# Altium schematic sheet Main.SchDoc
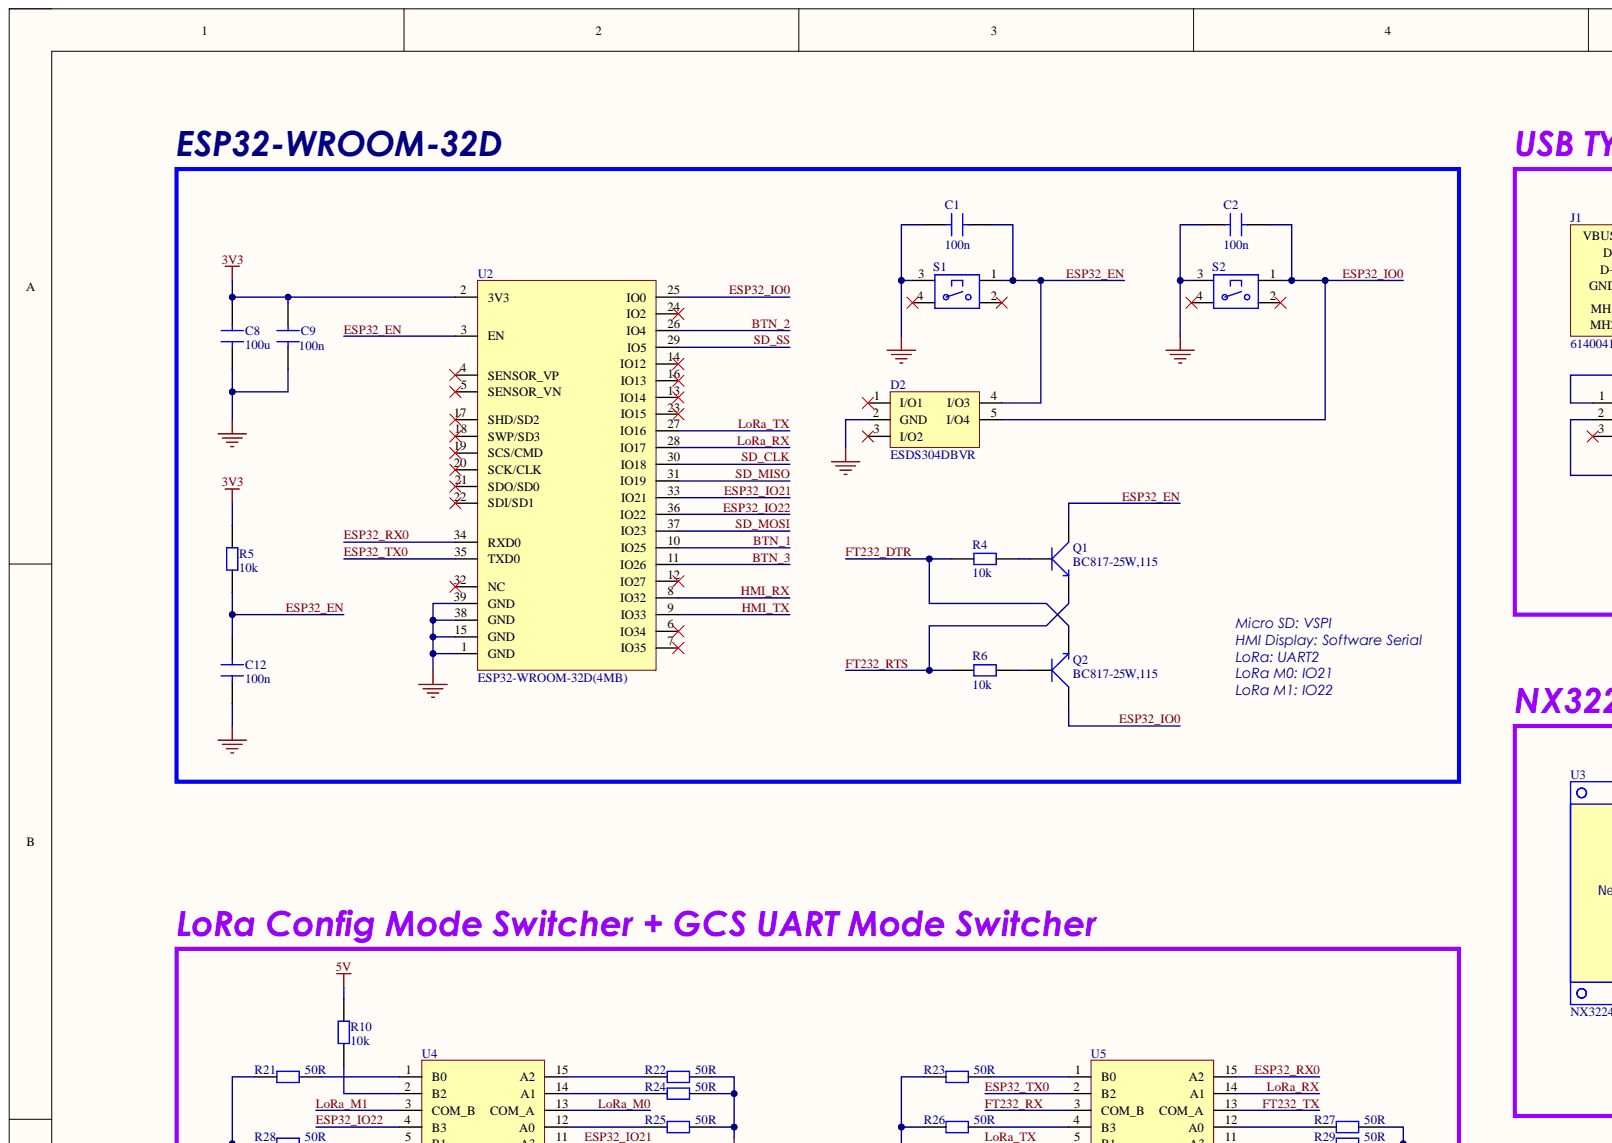
[diagram p0: Main.SchDoc, top left]
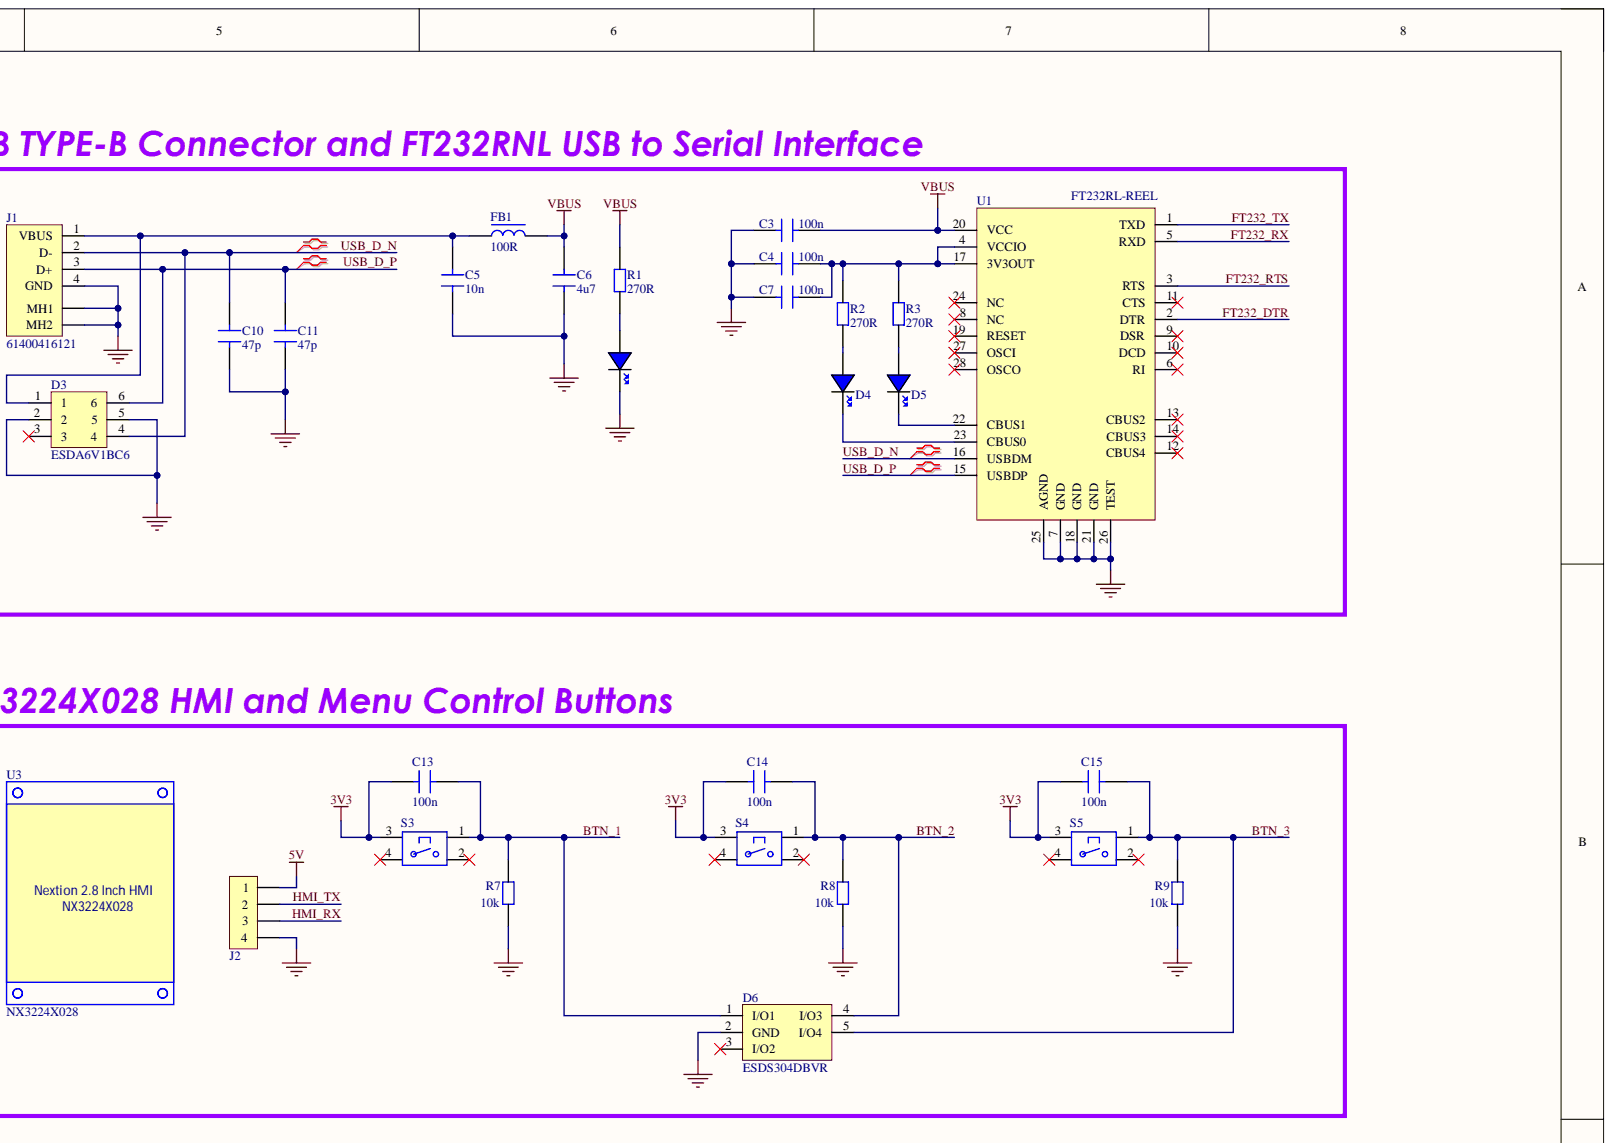
[diagram p1: Main.SchDoc, top right]
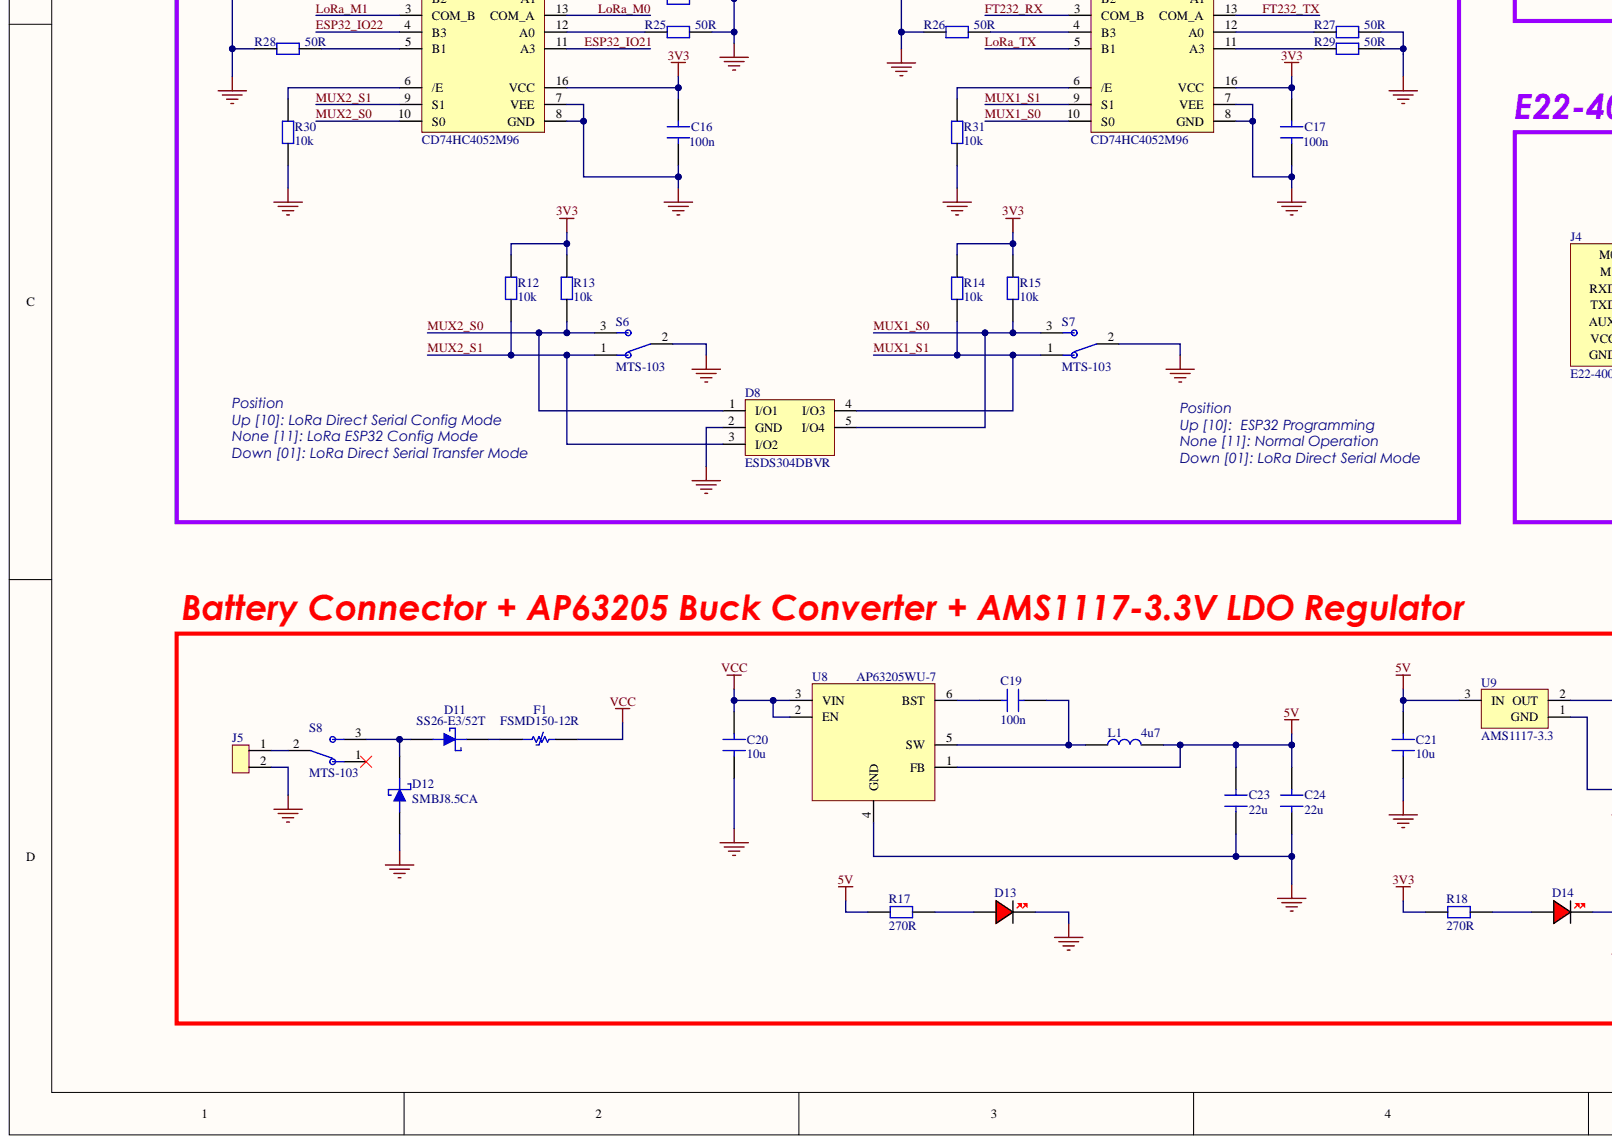
[diagram p2: Main.SchDoc, bottom left]
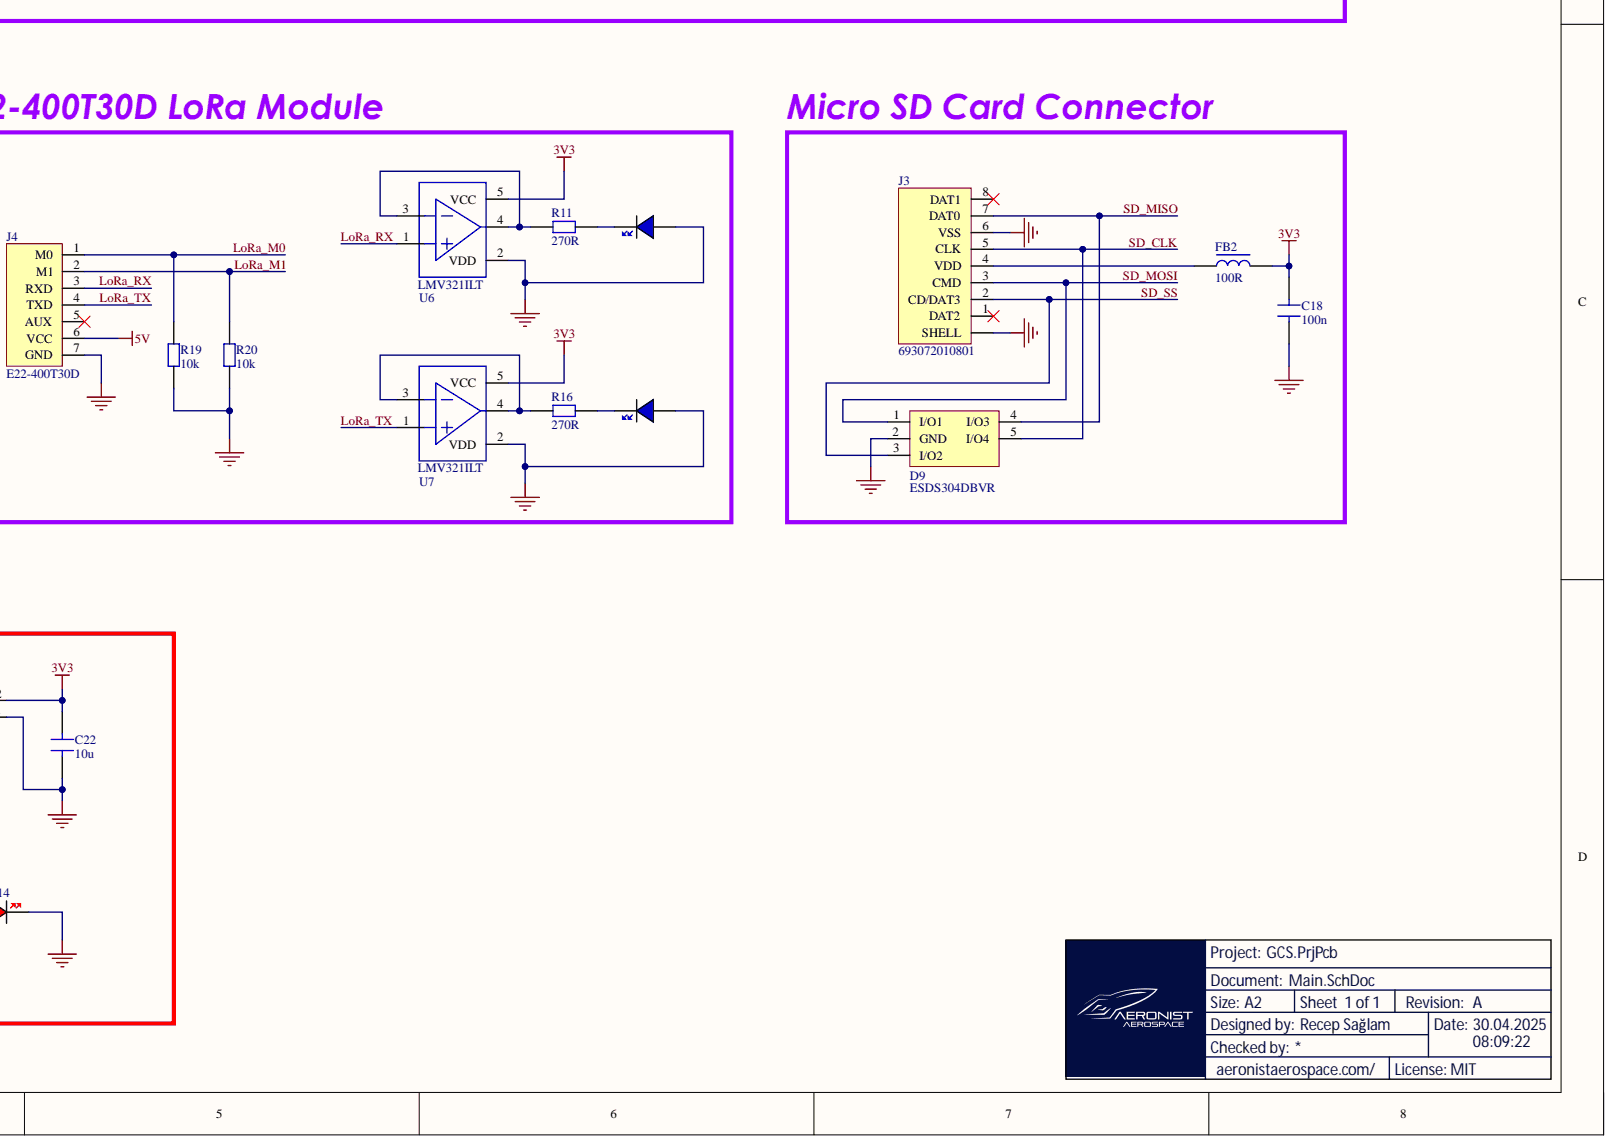
[diagram p3: Main.SchDoc, bottom right]

## Parts
C1  100n  [CAP 0805]
C2  100n  [CAP 0805]
C3  100n  [CAP 0805]
C4  100n  [CAP 0805]
C5  10n  [CAP 0805]
C6  4u7  [CAP 0805]
C7  100n  [CAP 0805]
C8  100u  [CAP 1210]
C9  100n  [CAP 0805]
C10  47p  [CAP 0805]
C11  47p  [CAP 0805]
C12  100n  [CAP 0805]
C13  100n  [CAP 0805]
C14  100n  [CAP 0805]
C15  100n  [CAP 0805]
C16  100n  [CAP 0805]
C17  100n  [CAP 0805]
C18  100n  [CAP 0805]
C19  100n  [CAP 0805]
C20  10u  [CAP 1210]
C21  10u  [CAP 0805]
C22  10u  [CAP 0805]
C23  22u  [CAP 1210]
C24  22u  [CAP 1210]
D1  LED 0805 BLUE  [LED 0805 BLUE]  (value not drawn)
D2  ESDS304DBVR  [SOT-23-5]
D3  ESDA6V1BC6  [SOT-23-6]
D4  LED 0805 BLUE  [LED 0805 BLUE]  (value not drawn)
D5  LED 0805 BLUE  [LED 0805 BLUE]  (value not drawn)
D6  ESDS304DBVR  [SOT-23-5]
D7  LED 0805 BLUE  [LED 0805 BLUE]  (value not drawn)
D8  ESDS304DBVR  [SOT-23-5]
D9  ESDS304DBVR  [SOT-23-5]
D10  LED 0805 BLUE  [LED 0805 BLUE]  (value not drawn)
D11  SS26-E3/52T  [DO-214AA]
D12  SMBJ8.5CA  [SMBJ12CA-13-F]
D13  LED 0805 RED  [LED 0805 RED]  (value not drawn)
D14  LED 0805 RED  [LED 0805 RED]  (value not drawn)
F1  FSMD150-12R  [0ZCG0075FF2C]
FB1  100R  [FB 0805]
FB2  100R  [FB 0805]
J1  61400416121  [61400416121]
J2  1x4 HEADER MALE  [1X4 HEADER MALE]  (value not drawn)
J3  693072010801  [693072010801]
J4  E22-400T30D  [LoRa E22-433T30D H]
J5  KF350 2P Terminal  [KF350 2P]  (value not drawn)
L1  4u7  [IHLP2020CZER4R7M11]
Q1  BC817-25W,115  [BC817-25]
Q2  BC817-25W,115  [BC817-25]
R1  270R  [RES 0805]
R2  270R  [RES 0805]
R3  270R  [RES 0805]
R4  10k  [RES 0805]
R5  10k  [RES 0805]
R6  10k  [RES 0805]
R7  10k  [RES 0805]
R8  10k  [RES 0805]
R9  10k  [RES 0805]
R10  10k  [RES 0805]
R11  270R  [RES 0805]
R12  10k  [RES 0805]
R13  10k  [RES 0805]
R14  10k  [RES 0805]
R15  10k  [RES 0805]
R16  270R  [RES 0805]
R17  270R  [RES 0805]
R18  270R  [RES 0805]
R19  10k  [RES 0805]
R20  10k  [RES 0805]
R21  50R  [RES 0805]
R22  50R  [RES 0805]
R23  50R  [RES 0805]
R24  50R  [RES 0805]
R25  50R  [RES 0805]
R26  50R  [RES 0805]
R27  50R  [RES 0805]
R28  50R  [RES 0805]
R29  50R  [RES 0805]
R30  10k  [RES 0805]
R31  10k  [RES 0805]
S1  FSM4JSMATR  [FSM4JSMATR]  (value not drawn)
S2  FSM4JSMATR  [FSM4JSMATR]  (value not drawn)
S3  FSM4JSMATR  [FSM4JSMATR]  (value not drawn)
S4  FSM4JSMATR  [FSM4JSMATR]  (value not drawn)
S5  FSM4JSMATR  [FSM4JSMATR]  (value not drawn)
S6  MTS-103  [MTS-103]
S7  MTS-103  [MTS-103]
S8  MTS-103  [MTS-103]
U1  FT232RL-REEL  [FT232RL]
U2  ESP32-WROOM-32D(4MB)  [ESP32-WROOM-32]
U3  NX3224X028  [NX32224X028]
U4  CD74HC4052M96  [SOIC-16]
U5  CD74HC4052M96  [SOIC-16]
U6  LMV321ILT  [SOT-23-5]
U7  LMV321ILT  [SOT-23-5]
U8  AP63205WU-7  [AP63205]
U9  AMS1117-3.3  [SOT-223]

## Nets
3V3: C8.2 C9.2 C13.2 C14.1 C15.2 C16.2 C17.2 C18.1 C22.1 FB2.2 R5.2 R12.2 R13.2 R14.2 R15.2 R18.1 S3.3 S4.3 S5.3 U2.2(3V3) U4.16(VCC) U5.16(VCC) U6.5(VCC) U7.5(VCC) U9.2
5V: C21.1 C23.2 C24.2 J2.1 J4.6(VCC) L1.2 R10.2 R17.1 U8.1(FB) U9.3
GND: C1.2 C2.2 C3.2 C4.2 C5.1 C6.1 C7.2 C8.1 C9.1 C10.1 C11.1 C12.2 C16.1 C17.1 C18.2 C20.1 C21.2 C22.2 C23.1 C24.1 D1.K D2.2(GND) D3.2 D3.5 D6.2(GND) D7.A D8.2(GND) D9.2(GND) D10.A D12.1 D13.K D14.K J1.4(GND) J1.MH1 J1.MH2 J2.4 J3.6(VSS) J3.SHELL J4.7(GND) J5.2 R7.2 R8.2 R9.2 R19.1 R20.1 R21.2 R22.1 R23.2 R24.1 R25.1 R26.2 R27.1 R28.2 R29.1 R30.1 R31.1 S1.3 S2.3 S6.2 S7.2 U1.7(GND) U1.18(GND) U1.21(GND) U1.25(AGND) U1.26(TEST) U2.1(GND) U2.15(GND) U2.38(GND) U2.39(GND) U4.7(VEE) U4.8(GND) U5.7(VEE) U5.8(GND) U6.2(VDD) U7.2(VDD) U8.4(GND) U9.1
VBUS: C3.1 C6.2 FB1.2 R1.1 U1.20(VCC)
VCC: C20.2 F1.2 U8.2(EN) U8.3(VIN)
BTN_1: C13.1 D6.1(I/O1) R7.1 S3.1 U2.10(IO25)
BTN_2: C14.2 D6.4(I/O3) R8.1 S4.1 U2.26(IO4)
BTN_3: C15.1 D6.5(I/O4) R9.1 S5.1 U2.11(IO26)
ESP32_EN: C1.1 C12.1 D2.4(I/O3) Q1.3 R5.1 S1.1 U2.3(EN)
ESP32_IO0: C2.1 D2.5(I/O4) Q2.3 S2.1 U2.25(IO0)
ESP32_IO21: U2.33(IO21) U4.11(A3)
ESP32_IO22: U2.36(IO22) U4.4(B3)
ESP32_RX0: U2.34(RXD0) U5.15(A2)
ESP32_TX0: U2.35(TXD0) U5.2(B2)
FT232_DTR: Q2.2 R4.2 U1.2(DTR)
FT232_RTS: Q1.2 R6.2 U1.3(RTS)
FT232_RX: U1.5(RXD) U5.3(COM_B)
FT232_TX: U1.1(TXD) U5.13(COM_A)
HMI_RX: J2.3 U2.8(IO32)
HMI_TX: J2.2 U2.9(IO33)
LoRa_M0: J4.1(M0) R19.2 U4.13(COM_A)
LoRa_M1: J4.2(M1) R20.2 U4.3(COM_B)
LoRa_RX: J4.3(RXD) U2.28(IO17) U5.14(A1) U6.1
LoRa_TX: J4.4(TXD) U2.27(IO16) U5.5(B1) U7.1
MUX1_S0: D8.5(I/O4) R15.1 S7.3 U5.10(S0)
MUX1_S1: D8.4(I/O3) R14.1 S7.1 U5.9(S1)
MUX2_S0: D8.1(I/O1) R13.1 S6.3 U4.10(S0)
MUX2_S1: D8.3(I/O2) R12.1 S6.1 U4.9(S1)
SD_CLK: D9.5(I/O4) J3.5(CLK) U2.30(IO18)
SD_MISO: D9.4(I/O3) J3.7(DAT0) U2.31(IO19)
SD_MOSI: D9.1(I/O1) J3.3(CMD) U2.37(IO23)
SD_SS: D9.3(I/O2) J3.2(CD/DAT3) U2.29(IO5)
USB_D_N: C10.2 D3.4 J1.2(D-) U1.16(USBDM)
USB_D_P: C11.2 D3.6 J1.3(D+) U1.15(USBDP)
NetC4_1: C4.1 C7.1 R2.1 R3.1 U1.4(VCCIO) U1.17(3V3OUT)
NetC5_2: C5.2 D3.1 FB1.1 J1.1(VBUS)
NetC19_1: C19.1 L1.1 U8.5(SW)
NetC19_2: C19.2 U8.6(BST)
NetD1_A: D1.A R1.2
NetD4_A: D4.A R2.2
NetD4_K: D4.K U1.23(CBUS0)
NetD5_A: D5.A R3.2
NetD5_K: D5.K U1.22(CBUS1)
NetD7_K: D7.K R11.2
NetD10_K: D10.K R16.2
NetD11_1: D11.1 F1.1
NetD11_2: D11.2 D12.2 S8.3
NetD13_A: D13.A R17.2
NetD14_A: D14.A R18.2
NetFB2_1: FB2.1 J3.4(VDD)
NetJ5_1: J5.1 S8.2
NetQ1_1: Q1.1 R4.1
NetQ2_1: Q2.1 R6.1
NetR10_1: R10.1 U4.2(B2)
NetR11_1: R11.1 U6.3 U6.4
NetR16_1: R16.1 U7.3 U7.4
NetR21_1: R21.1 U4.1(B0)
NetR22_2: R22.2 U4.15(A2)
NetR23_1: R23.1 U5.1(B0)
NetR24_2: R24.2 U4.14(A1)
NetR25_2: R25.2 U4.12(A0)
NetR26_1: R26.1 U5.4(B3)
NetR27_2: R27.2 U5.12(A0)
NetR28_1: R28.1 U4.5(B1)
NetR29_2: R29.2 U5.11(A3)
NetR30_2: R30.2 U4.6(/E)
NetR31_2: R31.2 U5.6(/E)

Not connected: D2 1(I/O1) 3(I/O2); D3 3; D6 3(I/O2); J3 1(DAT2) 8(DAT1); J4 5(AUX); S1 2 4; S2 2 4; S3 2 4; S4 2 4; S5 2 4; S8 1; U1 6(RI) 8(NC) 9(DSR) 10(DCD) 11(CTS) 12(CBUS4) 13(CBUS2) 14(CBUS3) 19(RESET) 24(NC) 27(OSCI) 28(OSCO); U2 4(SENSOR_VP) 5(SENSOR_VN) 6(IO34) 7(IO35) 12(IO27) 13(IO14) 14(IO12) 16(IO13) 17(SHD/SD2) 18(SWP/SD3) 19(SCS/CMD) 20(SCK/CLK) 21(SDO/SD0) 22(SDI/SD1) 23(IO15) 24(IO2) 32(NC)
